# Altium schematic sheet p1_bt_sorry.SchDoc(p1_bt_sorry) (continued)

## Library data of the parts
C1 C10 C12 = 10uF 10V 0402: CAP CER 10UF 10V X5R 0402; Manufacturer Part Number: CL05A106MP5NUNC; Manufacturer: Samsung; Tolerance: 20%; Width: 0.02inch; Voltage Rating: 10V; Thickness: 0.028inch; Termination: Wraparound; Supplier Part Number 1: 1276-1450-1-ND; Supplier 1: Digi-Key; Stock 1: 2282441; Radiation Hardening: No; Pricing 1: 1=0.4, 10=0.277, 100=0.1663, 500=0.1247, 1000=0.1053, 2500=0.0998, 5000=0.0943 (USD); Packaging: Tape and Reel; Package Quantity: 1; Mount: Surface Mount; Min Operating Temperature: -55°C; Max Operating Temperature: 85°C; Library Name: Capacitors.SchLib; Length: 1mm; Lead Free: Lead Free; Dielectric: X5R; Depth: 0.5mm; Contact Plating: Nickel,Tin; ComponentLink2URL: https://datasheet.ciiva.com/26838/getdatasheetpartid-745310-26838170.pdf; ComponentLink2Description: https://datasheet.ciiva.com/26838/getdatasheetpartid-745310-26838170.pdf; ComponentLink1URL: https://datasheet.ciiva.com/25721/cl05a106mp5nunc-25721584.pdf; ComponentLink1Description: https://datasheet.ciiva.com/25721/cl05a106mp5nunc-25721584.pdf; Component Kind: Standard; Case Code (Metric): 1005; Case Code (Imperial): 0402; Case/Package: 0402; Capacitance: 10uF
C2 C3 C9 = 4.7uF 16V 0402: CAP CER 4.7UF 16V X5R 0402; Manufacturer Part Number: CL05A475MO5NUNC; Manufacturer: Samsung; Tolerance: 20%; Width: 0.02inch; Voltage Rating: 16V; Thickness: 0.022inch; Supplier Part Number 1: 1276-6836-1-ND; Supplier 1: Digi-Key; Stock 1: 282710; Pricing 1: 1=0.36, 10=0.248, 100=0.1485, 500=0.1114, 1000=0.0941, 2500=0.0891, 5000=0.0842 (USD); Packaging: Tape and Reel; Min Operating Temperature: -55°C; Max Operating Temperature: 85°C; Library Name: Capacitors.SchLib; Length: 0.039inch; Dielectric: X5R; Component Kind: Standard; Case Code (Metric): 1005; Case Code (Imperial): 0402; Case/Package: 0402; Capacitance: 4.7uF
C4 C6 = 1uF 25V 0402: CAP CER 1UF 25V X5R 0402; Manufacturer Part Number: TMK105BJ105MV-F; Manufacturer: Taiyo Yuden; Tolerance: 20%; Width: 0.02inch; Voltage Rating (DC): 25V; Voltage Rating: 25V; Thickness: 0.022inch; Termination: SMD/SMT; Supplier Part Number 1: 587-3245-1-ND; Supplier 1: Digi-Key; Stock 1: 113342; Radiation Hardening: No; Pricing 1: 1=0.2, 10=0.14, 100=0.066, 500=0.0463, 1000=0.0383, 2500=0.0357, 5000=0.0326 (USD); Packaging: Tape and Reel; Package Quantity: 1; Mount: Surface Mount; Min Operating Temperature: -55°C; Max Operating Temperature: 85°C; Library Name: Capacitors.SchLib; Length: 1mm; Height: 0.5mm; Halogen Free: Halogen Free; Dielectric: X5R; Depth: 0.5mm; Composition: Ceramic; ComponentLink4URL: https://datasheet.ciiva.com/30154/128tmk105bj105mv-f-30154639.pdf; ComponentLink4Description: https://datasheet.ciiva.com/30154/128tmk105bj105mv-f-30154639.pdf; ComponentLink3URL: https://datasheet.ciiva.com/13404/mlcc-all-e-541559-13404206.pdf; ComponentLink3Description: https://datasheet.ciiva.com/13404/mlcc-all-e-541559-13404206.pdf; ComponentLink2URL: https://datasheet.ciiva.com/15922/tmk105bj105mv-f-pdf-15922317.pdf; ComponentLink2Description: https://datasheet.ciiva.com/15922/tmk105bj105mv-f-pdf-15922317.pdf; ComponentLink1URL: https://datasheet.ciiva.com/11596/tmk105bj105mv-f-ss-11596466.pdf; ComponentLink1Description: https://datasheet.ciiva.com/11596/tmk105bj105mv-f-ss-11596466.pdf; Component Kind: Standard; Case Code (Metric): 1005; Case Code (Imperial): 0402; Case/Package: 0402; Capacitance: 1uF
C5 C7 C8 = 0.1uF 25V 0402: CAP CER 0.1UF 25V X7R 0402; Manufacturer Part Number: TMK105B7104KVHF; Manufacturer: Taiyo Yuden; Tolerance: 10%; Capacitance: 100nF; Width: 0.02inch; Weight: 2.3E-05oz; Voltage Rating (DC): 25V; Voltage Rating: 25V; Thickness: 0.022inch; Termination: SMD/SMT; Supplier Part Number 1: 587-3773-1-ND; Supplier 1: Digi-Key; Stock 1: 100000; Pricing 1: 1=0.12, 10=0.087, 100=0.0387, 500=0.02766, 1000=0.02173, 2500=0.01975, 5000=0.01817 (USD); Packaging: Tape and Reel; Package Quantity: 10000; Mount: Surface Mount; Min Operating Temperature: -55°C; Max Operating Temperature: 125°C; Library Name: Capacitors.SchLib; Length: 0.039inch; Lead Free: Lead Free; Height: 0.5mm; Halogen Free: Halogen Free; Dielectric: X7R; ComponentLink4URL: http://www.mouser.com/ds/2/396/mlcc11_hq_e-541564.pdf; ComponentLink4Description: http://www.mouser.com/ds/2/396/mlcc11_hq_e-541564.pdf; ComponentLink3URL: https://www.yuden.co.jp/productdata/catalog/mlcc01_hq_e.pdf; ComponentLink3Description: https://www.yuden.co.jp/productdata/catalog/mlcc01_hq_e.pdf; ComponentLink2URL: http://www.yuden.co.jp/ut/product/category/capacitor/putpdf/TMK105B7104KVHF_SS.pdf; ComponentLink2Description: http://www.yuden.co.jp/ut/product/category/capacitor/putpdf/TMK105B7104KVHF_SS.pdf; ComponentLink1URL: http://ds.yuden.co.jp/TYCOMPAS/ut/detail.do?productNo=TMK105B7104KVHF&fileName=TMK105B7104KVHF_SS&mode=specSheetDownload; ComponentLink1Description: http://ds.yuden.co.jp/TYCOMPAS/ut/detail.do?productNo=TMK105B7104KVHF&fileName=TMK105B7104KVHF_SS&mode=specSheetDownload; Component Kind: Standard; Case Code (Metric): 1005; Case Code (Imperial): 0402; Case/Package: 0402
C11 = 10000pF 25V 0402: CAP CER 10000PF 25V X7R 0402; Manufacturer Part Number: GRM155R71E103KA01D; Manufacturer: Murata; Tolerance: 10%; Material: Ceramic; Insulation Resistance: 10GR; Capacitance: 10nF; Width: 0.5mm; Voltage Rating (DC): 25V; Voltage Rating: 25V; Voltage: 25V; Thickness: 0.022inch; Termination: SMD/SMT; Supplier Part Number 1: 490-1312-1-ND; Supplier 1: Digi-Key; Stock 1: 4968292; REACH SVHC: No SVHC; Radiation Hardening: No; Pricing 1: 1=0.1, 10=0.014, 100=0.0064, 500=0.00456, 1000=0.00358, 2500=0.00325, 5000=0.00299 (USD); Packaging: Tape and Reel; Package Quantity: 1; Number of Pins: 2; Mount: Surface Mount; Min Operating Temperature: -55°C; Max Operating Temperature: 125°C; Library Name: Capacitors.SchLib; Length: 1mm; Lead Free: Lead Free; Height: 0.5mm; Dielectric Material: Ceramic; Dielectric: X7R; Depth: 0.5mm; ComponentLink10URL: https://datasheet.ciiva.com/29199/454154-da-01-en-vs-kondensator-0402-29199817.pdf; ComponentLink10Description: https://datasheet.ciiva.com/29199/454154-da-01-en-vs-kondensator-0402-29199817.pdf; ComponentLink9URL: https://datasheet.ciiva.com/129/partnumbering-e-01-129743.pdf; ComponentLink9Description: https://datasheet.ciiva.com/129/partnumbering-e-01-129743.pdf; ComponentLink8URL: https://datasheet.ciiva.com/129/partnumbering-e-01-129742.pdf; ComponentLink8Description: https://datasheet.ciiva.com/129/partnumbering-e-01-129742.pdf; ComponentLink7URL: https://datasheet.ciiva.com/129/partnumbering-e-01-129741.pdf; ComponentLink7Description: https://datasheet.ciiva.com/129/partnumbering-e-01-129741.pdf; ComponentLink6URL: https://datasheet.ciiva.com/3777/3813grm155r71e103ka0123-3777115.pdf; ComponentLink6Description: https://datasheet.ciiva.com/3777/3813grm155r71e103ka0123-3777115.pdf; ComponentLink5URL: https://datasheet.ciiva.com/16199/product-16199034.pdf; ComponentLink5Description: https://datasheet.ciiva.com/16199/product-16199034.pdf; ComponentLink4URL: https://datasheet.ciiva.com/7937/1747448-7937621.pdf; ComponentLink4Description: https://datasheet.ciiva.com/7937/1747448-7937621.pdf; ComponentLink3URL: https://datasheet.ciiva.com/28534/1699889-28534148.pdf; ComponentLink3Description: https://datasheet.ciiva.com/28534/1699889-28534148.pdf; ComponentLink2URL: https://datasheet.ciiva.com/18184/getdatasheetpartid-161489-18184689.pdf; ComponentLink2Description: https://datasheet.ciiva.com/18184/getdatasheetpartid-161489-18184689.pdf; ComponentLink1URL: https://datasheet.ciiva.com/11786/0900766b807a1cab-11786320.pdf; ComponentLink1Description: https://datasheet.ciiva.com/11786/0900766b807a1cab-11786320.pdf; Component Kind: Standard; Case Code (Metric): 1005; Case Code (Imperial): 0402; Case/Package: 0402
C13 = 0.015uF 16V 0402: CAP CER 0.015UF 16V X7R 0402; Manufacturer Part Number: GRM155R71C153KA01J; Manufacturer: Murata; Tolerance: 10%; Capacitance: 15nF; Width: 0.02inch; Voltage Rating (DC): 16V; Voltage Rating: 16V; Thickness: 0.022inch; Termination: SMD/SMT; Supplier Part Number 1: 490-6329-1-ND; Supplier 1: Digi-Key; Stock 1: 43188; Radiation Hardening: No; Pricing 1: 1=0.1, 10=0.044, 100=0.0197, 500=0.01408, 1000=0.01107, 2500=0.01006, 5000=0.00926 (USD); Packaging: Tape and Reel; Package Quantity: 1; Mount: Surface Mount; Min Operating Temperature: -55°C; Max Operating Temperature: 125°C; Library Name: Capacitors.SchLib; Length: 1mm; Height: 0.5mm; Dielectric: X7R; Depth: 0.5mm; ComponentLink3URL: https://datasheet.ciiva.com/6271/partnumbering-e-01-6271151.pdf; ComponentLink3Description: https://datasheet.ciiva.com/6271/partnumbering-e-01-6271151.pdf; ComponentLink2URL: https://datasheet.ciiva.com/11619/product-11619501.pdf; ComponentLink2Description: https://datasheet.ciiva.com/11619/product-11619501.pdf; ComponentLink1URL: https://datasheet.ciiva.com/6271/partnumbering-e-01-6271147.pdf; ComponentLink1Description: https://datasheet.ciiva.com/6271/partnumbering-e-01-6271147.pdf; Component Kind: Standard; Case Code (Metric): 1005; Case Code (Imperial): 0402; Case/Package: 0402
D1 = ESDAXLC6-1BU2: TVS DIODE 3V 17V ST0201; Manufacturer: STMicroelectronics; Test Current: 1mA; Power Line Protection: No; Polarity: Bidirectional; Peak Pulse Power: 40W; Peak Pulse Current: 1A; Number of Channels: 1; Min Breakdown Voltage: 6V; Max Surge Current: 1.3A; Max Reverse Leakage Current: 70nA; ESD Protection: Yes; Element Configuration: Single; Capacitance: 0.5pF; Width: 0.35mm; Termination: SMD/SMT; Supplier Part Number 1: 497-13414-1-ND; Supplier 1: Digi-Key; Stock 1: 25159; RoHS: Yes; Reverse Standoff Voltage: 3V; Radiation Hardening: No; Pricing 1: 1 = 0.42000, 10 = 0.31900, 100 = 0.19890, 500 = 0.13610, 1000 = 0.10469 (USD); Packaging: Tape and Reel; Package Quantity: 1; Number of Pins: 2; Number of Bidirectional Channels: 1; Mount: Surface Mount; Min Operating Temperature: -40°C; Max Operating Temperature: 150°C; Manufacturer Part Number: ESDAXLC6-1BU2; Library Name: Diodes.SchLib; Length: 0.6mm; Leakage Current: 70nA; Lead Free: Lead Free; Height: 0.33mm; Direction: Bidirectional; Depth: 0.3mm; Composition: Zener; ComponentLink10URL: https://datasheet.ciiva.com/41443/001183999-da-01-en-tvs-diode-3v-1-esdaxlc6-1bu2-st-0201-stm-41443438.pdf?src-supplier=Conrad; ComponentLink10Description: https://datasheet.ciiva.com/41443/001183999-da-01-en-tvs-diode-3v-1-esdaxlc6-1bu2-st-0201-stm-41443438.pdf?src-supplier=Conrad; ComponentLink9URL: https://datasheet.ciiva.com/24017/dm00065800-24017847.pdf?src-supplier=Mouser; ComponentLink9Description: https://datasheet.ciiva.com/24017/dm00065800-24017847.pdf?src-supplier=Mouser; ComponentLink8URL: https://datasheet.ciiva.com/24017/dm00065800-24017847.pdf?src-supplier=Avnet; ComponentLink8Description: https://datasheet.ciiva.com/24017/dm00065800-24017847.pdf?src-supplier=Avnet; ComponentLink7URL: https://datasheet.ciiva.com/4510/esd11b-d-4510297.pdf?src-supplier=Mouser; ComponentLink7Description: https://datasheet.ciiva.com/4510/esd11b-d-4510297.pdf?src-supplier=Mouser; ComponentLink6URL: https://datasheet.ciiva.com/11193/esd11b-d-11193656.pdf?src-supplier=Avnet; ComponentLink6Description: https://datasheet.ciiva.com/11193/esd11b-d-11193656.pdf?src-supplier=Avnet; ComponentLink5URL: https://datasheet.ciiva.com/6577/esd11b-d-6577815.pdf?src-supplier=Digi-Key; ComponentLink5Description: https://datasheet.ciiva.com/6577/esd11b-d-6577815.pdf?src-supplier=Digi-Key; ComponentLink4URL: https://datasheet.ciiva.com/6577/esd11b-d-6577814.pdf?src-supplier=Digi-Key; ComponentLink4Description: https://datasheet.ciiva.com/6577/esd11b-d-6577814.pdf?src-supplier=Digi-Key; ComponentLink3URL: http://www.onsemi.com/pub_link/Collateral/152AA.PDF; ComponentLink3Description: Package Specification; ComponentLink2URL: http://www.onsemi.cn/pub_link/Collateral/ESD11B-D.PDF; ComponentLink2Description: Datasheet; ComponentLink1URL: http://www.onsemi.com/; ComponentLink1Description: Manufacturer URL; Component Kind: Standard; Clamping Voltage: 17V; Case Code (Metric): 0603; Case Code (Imperial): 0201; Case/Package: 0201; Breakdown Voltage: 6V
D2 D6 = Green 0603 LED: LED GREEN CLEAR 0603 SMD; Manufacturer Part Number: 598-8070-107F; Manufacturer: Dialight; Wavelength: 570nm; Viewing Angle: 140°; Test Current: 20mA; Power Dissipation: 60mW; Number of LEDs: 1; Luminous Intensity: 20mcd; Lens Style: Clear,Diffused,Colorless,Rectangular; Forward Voltage: 2V; Dominant Wavelength: 568nm; Width: 0.8mm; Supplier Part Number 1: 350-2035-1-ND; Supplier 1: Digi-Key; Stock 1: 147636; REACH SVHC: No SVHC; Radiation Hardening: No; Pricing 1: 1=0.45, 10=0.327, 25=0.2504, 100=0.1634, 250=0.1198, 500=0.1016, 1000=0.0835 (USD); Packaging: Tape and Reel; Package Quantity: 4000; Number of Pins: 2; Number of Elements: 1; Mount: Surface Mount; Min Operating Temperature: -30°C; Max Operating Temperature: 100°C; Library Name: Diodes.SchLib; Lens Colour: Clear; Length: 1.6mm; Lead Free: Lead Free; Illumination Colour: Green; Height: 0.7mm; Forward Current: 20mA; Depth: 0.8mm; ComponentLink13URL: https://datasheet.ciiva.com/16400/smt-selector-guide-16400463.pdf; ComponentLink13Description: https://datasheet.ciiva.com/16400/smt-selector-guide-16400463.pdf; ComponentLink12URL: https://datasheet.ciiva.com/22749/1484480-22749228.pdf; ComponentLink12Description: https://datasheet.ciiva.com/22749/1484480-22749228.pdf; ComponentLink11URL: https://datasheet.ciiva.com/15117/getdatasheetpartid-146438-15117836.pdf; ComponentLink11Description: https://datasheet.ciiva.com/15117/getdatasheetpartid-146438-15117836.pdf; ComponentLink10URL: https://datasheet.ciiva.com/30188/mdei5980603-30188139.pdf; ComponentLink10Description: https://datasheet.ciiva.com/30188/mdei5980603-30188139.pdf; ComponentLink9URL: https://datasheet.ciiva.com/15965/mdei5980603-15965419.pdf; ComponentLink9Description: https://datasheet.ciiva.com/15965/mdei5980603-15965419.pdf; ComponentLink8URL: https://datasheet.ciiva.com/14605/70081990-14605530.pdf; ComponentLink8Description: https://datasheet.ciiva.com/14605/70081990-14605530.pdf; ComponentLink7URL: https://datasheet.ciiva.com/2110/598-series-0603-pkg-2110167.pdf; ComponentLink7Description: https://datasheet.ciiva.com/2110/598-series-0603-pkg-2110167.pdf; ComponentLink6URL: https://datasheet.ciiva.com/2110/598-series-0603-pkg-2110166.pdf; ComponentLink6Description: https://datasheet.ciiva.com/2110/598-series-0603-pkg-2110166.pdf; ComponentLink5URL: https://datasheet.ciiva.com/1243/1484480-1243894.pdf; ComponentLink5Description: https://datasheet.ciiva.com/1243/1484480-1243894.pdf; ComponentLink4URL: https://datasheet.ciiva.com/2110/598-series-0603-pkg-2110165.pdf; ComponentLink4Description: https://datasheet.ciiva.com/2110/598-series-0603-pkg-2110165.pdf; ComponentLink3URL: https://datasheet.ciiva.com/1243/1484480-1243893.pdf; ComponentLink3Description: https://datasheet.ciiva.com/1243/1484480-1243893.pdf; ComponentLink2URL: https://datasheet.ciiva.com/11774/0900766b80ae2975-11774257.pdf; ComponentLink2Description: https://datasheet.ciiva.com/11774/0900766b80ae2975-11774257.pdf; ComponentLink1URL: https://datasheet.ciiva.com/4688/598-selectorguide-18902-4688880.pdf; ComponentLink1Description: https://datasheet.ciiva.com/4688/598-selectorguide-18902-4688880.pdf; Component Kind: Standard; Colour: Green; Case Code (Metric): 1608; Case Code (Imperial): 0603; Case/Package: 0603
D3 = MMBD914LT1G: DIODE GEN PURP 100V 200MA SOT23; Manufacturer: ON Semiconductor; Reverse Recovery Time: 4ns; Power Dissipation: 300mW; Polarity: Standard; Peak Reverse Current: 5uA; Peak Non-Repetitive Surge Current: 500mA; Forward Voltage: 1V; Element Configuration: Single; Capacitance: 4pF; Width: 0.055inch; Voltage Rating (DC): 100V; Supplier Part Number 1: MMBD914LT1GOSCT-ND; Supplier 1: Digi-Key; Stock 1: 1313496; RoHS: Yes; Recovery Time: 4ns; REACH SVHC: No SVHC; Radiation Hardening: No; Pricing 1: 1 = 0.11000, 10 = 0.10000, 100 = 0.05450, 500 = 0.03352, 1000 = 0.02286 (USD); Packaging: Tape and Reel; Package Quantity: 1; Output Current: 200mA; Number of Pins: 3; Min Operating Temperature: -55°C; Max Surge Current: 500mA; Max Reverse Voltage (DC): 100V; Max Reverse Leakage Current: 5uA; Max Repetitive Reverse Voltage (Vrrm): 100V; Max Operating Temperature: 150°C; Max Forward Surge Current (Ifsm): 500mA; Manufacturer Part Number: MMBD914LT1G; Library Name: Diodes.SchLib; Length: 0.119inch; Lead Free: Lead Free; Height: 0.04inch; Halogen Free: Halogen Free; Forward Current: 200mA; Current Rating: 200mA; Contact Plating: Tin; ComponentLink8URL: https://datasheet.ciiva.com/16474/mmbd914lt1-d-16474303.pdf?src-supplier=Avnet+Asia; ComponentLink8Description: https://datasheet.ciiva.com/16474/mmbd914lt1-d-16474303.pdf?src-supplier=Avnet+Asia; ComponentLink7URL: https://datasheet.ciiva.com/16209/mmbd914lt1-d-16209147.pdf?src-supplier=Future+Electronics; ComponentLink7Description: https://datasheet.ciiva.com/16209/mmbd914lt1-d-16209147.pdf?src-supplier=Future+Electronics; ComponentLink6URL: https://datasheet.ciiva.com/22663/1571903-22663685.pdf?src-supplier=Element14; ComponentLink6Description: https://datasheet.ciiva.com/22663/1571903-22663685.pdf?src-supplier=Element14; ComponentLink5URL: https://datasheet.ciiva.com/1181/mmbd914lt1-d-1181205.pdf?src-supplier=Element14; ComponentLink5Description: https://datasheet.ciiva.com/1181/mmbd914lt1-d-1181205.pdf?src-supplier=Element14; ComponentLink4URL: https://datasheet.ciiva.com/1181/mmbd914lt1-d-1181204.pdf?src-supplier=Element14; ComponentLink4Description: https://datasheet.ciiva.com/1181/mmbd914lt1-d-1181204.pdf?src-supplier=Element14; ComponentLink3URL: https://datasheet.ciiva.com/915/mmbd914lt1-d-915329.pdf?src-supplier=Digi-Key; ComponentLink3Description: https://datasheet.ciiva.com/915/mmbd914lt1-d-915329.pdf?src-supplier=Digi-Key; ComponentLink2URL: https://datasheet.ciiva.com/915/mmbd914lt1-d-915328.pdf?src-supplier=Digi-Key; ComponentLink2Description: https://datasheet.ciiva.com/915/mmbd914lt1-d-915328.pdf?src-supplier=Digi-Key; ComponentLink1URL: https://datasheet.ciiva.com/1243/mmbd914lt1-d-1243184.pdf?src-supplier=Newark; ComponentLink1Description: https://datasheet.ciiva.com/1243/mmbd914lt1-d-1243184.pdf?src-supplier=Newark; Component Kind: Standard; Case/Package: SOT-23-3; Average Rectified Current: 200mA
D4 = PMEG2020EPK,315: DIODE SCHOTTKY 20V 2A 2DFN; Manufacturer: NXP Semiconductors; Reverse Recovery Time: 5ns; Peak Reverse Current: 70uA; Peak Non-Repetitive Surge Current: 5A; Natural Thermal Resistance: 20°C/W; Max Power Dissipation: 1.565W; Forward Voltage: 395mV; Element Configuration: Single; Supplier Part Number 1: 1727-1338-1-ND; Supplier 1: Digi-Key; Stock 1: 146420; Reverse Voltage (DC): 20V; Radiation Hardening: No; Pricing 1: 1=0.51, 10=0.387, 100=0.2411, 500=0.165, 1000=0.1269 (USD); Packaging: Tape and Reel; Package Quantity: 8000; Number of Pins: 2; Mount: Surface Mount; Min Operating Temperature: -55°C; Max Repetitive Reverse Voltage (Vrrm): 20V; Max Operating Temperature: 150°C; Max Forward Surge Current (Ifsm): 5A; Manufacturer Part Number: PMEG2020EPK,315; Library Name: Diodes.SchLib; Forward Current: 2A; ComponentLink4URL: https://datasheet.ciiva.com/25648/pmeg2020epk-25648600.pdf; ComponentLink4Description: https://datasheet.ciiva.com/25648/pmeg2020epk-25648600.pdf; ComponentLink3URL: https://datasheet.ciiva.com/24111/pmeg2020epk-268880-24111063.pdf; ComponentLink3Description: https://datasheet.ciiva.com/24111/pmeg2020epk-268880-24111063.pdf; ComponentLink2URL: https://datasheet.ciiva.com/29592/pmeg2020epk-29592901.pdf; ComponentLink2Description: https://datasheet.ciiva.com/29592/pmeg2020epk-29592901.pdf; ComponentLink1URL: https://datasheet.ciiva.com/29592/pmeg2020epk-29592900.pdf; ComponentLink1Description: https://datasheet.ciiva.com/29592/pmeg2020epk-29592900.pdf; Component Kind: Standard; Case/Package: SMD/SMT; Average Rectified Current: 2A
D5 = Blue 0603 LED: LED BLUE CLEAR 0603 SMD; Manufacturer Part Number: 598-8091-107F; Manufacturer: Dialight; Wavelength: 475nm; Viewing Angle: 140°; Test Current: 20mA; Power Dissipation: 70mW; Number of LEDs: 1; Luminous Intensity: 140mcd; Lens Style: Clear,Diffused,Colorless,Rectangular; Lens Colour: Clear,Colorless; Forward Voltage: 3.2V; Dominant Wavelength: 470nm; Width: 0.8mm; Supplier Part Number 1: 350-2037-1-ND; Supplier 1: Digi-Key; Stock 1: 42042; REACH SVHC: No SVHC; Radiation Hardening: No; Pricing 1: 1=0.75, 10=0.583, 25=0.4864, 100=0.4189, 250=0.374, 500=0.3216, 1000=0.2483 (USD); Packaging: Tape and Reel; Package Quantity: 4000; Number of Pins: 2; Number of Elements: 1; Mount: Surface Mount; Min Operating Temperature: -30°C; Max Operating Temperature: 100°C; Library Name: Diodes.SchLib; Lens Transparency: Clear; Length: 1.6mm; Lead Free: Lead Free; Illumination Colour: Blue; Height: 0.7mm; Forward Current: 20mA; Depth: 0.8mm; ComponentLink9URL: https://datasheet.ciiva.com/16400/smt-selector-guide-16400478.pdf; ComponentLink9Description: https://datasheet.ciiva.com/16400/smt-selector-guide-16400478.pdf; ComponentLink8URL: https://datasheet.ciiva.com/14603/70081992-14603963.pdf; ComponentLink8Description: https://datasheet.ciiva.com/14603/70081992-14603963.pdf; ComponentLink7URL: https://datasheet.ciiva.com/7970/25658-7970182.pdf; ComponentLink7Description: https://datasheet.ciiva.com/7970/25658-7970182.pdf; ComponentLink6URL: https://datasheet.ciiva.com/2111/598-series-0603-pkg-2111558.pdf; ComponentLink6Description: https://datasheet.ciiva.com/2111/598-series-0603-pkg-2111558.pdf; ComponentLink5URL: https://datasheet.ciiva.com/30240/mdei5980603-30240533.pdf; ComponentLink5Description: https://datasheet.ciiva.com/30240/mdei5980603-30240533.pdf; ComponentLink4URL: https://datasheet.ciiva.com/15965/mdei5980603-15965445.pdf; ComponentLink4Description: https://datasheet.ciiva.com/15965/mdei5980603-15965445.pdf; ComponentLink3URL: https://datasheet.ciiva.com/2111/598-series-0603-pkg-2111557.pdf; ComponentLink3Description: https://datasheet.ciiva.com/2111/598-series-0603-pkg-2111557.pdf; ComponentLink2URL: https://datasheet.ciiva.com/2111/598-series-0603-pkg-2111556.pdf; ComponentLink2Description: https://datasheet.ciiva.com/2111/598-series-0603-pkg-2111556.pdf; ComponentLink1URL: https://datasheet.ciiva.com/15118/getdatasheetpartid-194139-15118048.pdf; ComponentLink1Description: https://datasheet.ciiva.com/15118/getdatasheetpartid-194139-15118048.pdf; Component Kind: Standard; Colour: Blue; Case Code (Metric): 1608; Case Code (Imperial): 0603; Case/Package: 0603
J1 = USB-C Vertical: CONN RCPT USB3.1 TYPEC 24POS SMD; Manufacturer: Amphenol FCI; Supplier Part Number 1: 609-5380-1-ND; Supplier 1: Digi-Key; Stock 1: 6832; RoHS: No; Pricing 1: 1 = 2.67000, 10 = 2.41900, 25 = 2.27120, 50 = 2.17240, 100 = 2.07370 (USD); Manufacturer Part Number: 10132328-10011LF; Library Name: Connectors.SchLib; ComponentLink1URL: http://www.amphenol-icc.com/media/wysiwyg/files/drawing/10132328.pdf?src-supplier=Digi-Key; ComponentLink1Description: http://www.amphenol-icc.com/media/wysiwyg/files/drawing/10132328.pdf?src-supplier=Digi-Key; Component Kind: Standard
J2 = Tag Connect 6-Pin SWD: TAG CONNECT 6PIN SWD; Library Name: Connectors.SchLib; Component Kind: Standard
J3 = JST ZH 2-Pin PTH Vertical: CONN HEADER ZH TOP 2POS 1.5MM; Manufacturer: JST; Voltage Rating (DC): 50V; Panel Thickness: 3.5mm; Number of Positions: 2; Max Current Rating: 1A; Insulation Resistance: 500MR; Gender: Male; Contact Resistance: 30mR; Connector Type: Male Pin; Body Plating: Tin; Voltage Rating (AC): 50V; Voltage Rating: 50V; Termination: Solder; Supplier Part Number 1: 455-1657-ND; Supplier 1: Digi-Key; Stock 1: 123534; RoHS: Yes; REACH SVHC: No SVHC; Pricing 1: 1 = 0.17000, 10 = 0.16200, 100 = 0.09990, 500 = 0.08270, 1000 = 0.07237, 5000 = 0.06203, 10000 = 0.06031 (USD); Pitch: 1.5mm; Packaging: Bulk; Orientation: Straight; Number of Rows: 1; Number of Contacts: 2; Mount: Through Hole; Min Operating Temperature: -25°C; Max Voltage Rating (AC): 50V; Max Operating Temperature: 85°C; Material: Nylon; Manufacturer Part Number: B2B-ZR(LF)(SN); Library Name: Connectors.SchLib; Length: 4.5mm; Lead Pitch: 1.5mm; Lead Free: Lead Free; Housing Material: Polyamide; Housing Color: Beige; Flammability Rating: UL94 V-0; Fastening Type: Detent; Depth: 3.5mm; Current Rating: 1A; Contact Plating: Tin; Contact Mating Length: 0.094inch; Contact Material: Brass; ComponentLink5URL: https://datasheet.ciiva.com/712/ezh-712443.pdf?src-supplier=Digi-Key; ComponentLink5Description: https://datasheet.ciiva.com/712/ezh-712443.pdf?src-supplier=Digi-Key; ComponentLink4URL: https://datasheet.ciiva.com/8052/80551-8052026.pdf?src-supplier=Element14; ComponentLink4Description: https://datasheet.ciiva.com/8052/80551-8052026.pdf?src-supplier=Element14; ComponentLink3URL: https://datasheet.ciiva.com/25616/0900766b81147f94-25616906.pdf?src-supplier=RSComponents; ComponentLink3Description: https://datasheet.ciiva.com/25616/0900766b81147f94-25616906.pdf?src-supplier=RSComponents; ComponentLink2URL: https://datasheet.ciiva.com/11752/0900766b8082f580-11752167.pdf?src-supplier=RSComponents; ComponentLink2Description: https://datasheet.ciiva.com/11752/0900766b8082f580-11752167.pdf?src-supplier=RSComponents; ComponentLink1URL: https://datasheet.ciiva.com/26894/getdatasheetpartid-643133-26894438.pdf?src-supplier=Verical; ComponentLink1Description: https://datasheet.ciiva.com/26894/getdatasheetpartid-643133-26894438.pdf?src-supplier=Verical; Component Kind: Standard; Color: Natural
J4 = 10-Pin 2x5 2mm Header: CONN HEADER SMD 10POS 2MM; Manufacturer: Harwin; Row Spacing: 0.079inch; Number of Positions: 10; Max Current Rating: 1A; Gender: Male; Contact Resistance: 30mR; Connector Type: Male Pin; Termination: Solder; Supplier Part Number 1: 952-3345-ND; Supplier 1: Digikey; Stock 1: 6436; RoHS: Yes; Radiation Hardening: No; Pricing 1: 1 = 0.76000, 10 = 0.66600, 100 = 0.57420, 500 = 0.46980, 1000 = 0.41760, 5000 = 0.36540, 10000 = 0.34713 (USD); Pitch: 2mm; Packaging: Rail/Tube; Package Quantity: 250; Orientation: Straight; Number of Rows: 2; Number of Contacts: 10; Mount: Surface Mount; Min Operating Temperature: -40°C; Max Operating Temperature: 105°C; Manufacturer Part Number: M22-5320546; Library Name: Connectors.SchLib; Length: 10mm; Housing Material: Nylon; Housing Color: Black; Depth: 5.75mm; Contact Plating: Tin; Contact Mating Length: 0.138inch; Contact Material: Bronze; ComponentLink1URL: https://datasheet.ciiva.com/16735/m22-532-16735560.pdf?src-supplier=Avnet+Europe; ComponentLink1Description: https://datasheet.ciiva.com/16735/m22-532-16735560.pdf?src-supplier=Avnet+Europe; Component Kind: Standard; Color: Black
Q1 = DMP21D0UFB4-7B: MOSFET P-CH 20V 770MA 3DFN; Manufacturer: Diodes; Turn-On Delay Time: 7.1ns; Turn-Off Delay Time: 31.7ns; Rise Time: 8ns; Rds On Max: 495mR; Power Dissipation: 990mW; Number of Elements: 1; Input Capacitance: 80pF; Gate to Source Voltage (Vgs): 8V; Fall Time: 18.5ns; Element Configuration: Single; Drain to Source Resistance: 960mR; Drain to Source Breakdown Voltage: -20V; Continuous Drain Current (ID): 1.17A; Width: 0.65mm; Supplier Part Number 1: DMP21D0UFB4-7BDICT-ND; Supplier 1: Digi-Key; Stock 1: 167177; REACH SVHC: No SVHC; Radiation Hardening: No; Pricing 1: 1=0.4, 10=0.303, 100=0.1886, 500=0.129, 1000=0.0992 (USD); Packaging: Tape and Reel; Package Quantity: 1; Number of Pins: 3; Number of Channels: 1; Mount: Surface Mount; Min Operating Temperature: -55°C; Max Power Dissipation: 990mW; Max Operating Temperature: 150°C; Manufacturer Part Number: DMP21D0UFB4-7B; Library Name: ICs.SchLib; Length: 1.05mm; Lead Free: Lead Free; Height: 0.35mm; Drain to Source Voltage (Vdss): 20V; Contact Plating: Gold; ComponentLink6URL: https://datasheet.ciiva.com/13051/dmp21d0ufb4-13051690.pdf; ComponentLink6Description: https://datasheet.ciiva.com/13051/dmp21d0ufb4-13051690.pdf; ComponentLink5URL: https://datasheet.ciiva.com/30479/0900766b8115c367-30479913.pdf; ComponentLink5Description: https://datasheet.ciiva.com/30479/0900766b8115c367-30479913.pdf; ComponentLink4URL: https://datasheet.ciiva.com/30023/dmp21d0ufb4-30023815.pdf; ComponentLink4Description: https://datasheet.ciiva.com/30023/dmp21d0ufb4-30023815.pdf; ComponentLink3URL: https://datasheet.ciiva.com/6369/dmp21d0ufb4-6369015.pdf; ComponentLink3Description: https://datasheet.ciiva.com/6369/dmp21d0ufb4-6369015.pdf; ComponentLink2URL: https://datasheet.ciiva.com/6369/dmp21d0ufb4-6369014.pdf; ComponentLink2Description: https://datasheet.ciiva.com/6369/dmp21d0ufb4-6369014.pdf; ComponentLink1URL: https://datasheet.ciiva.com/6369/dmp21d0ufb4-6369012.pdf; ComponentLink1Description: https://datasheet.ciiva.com/6369/dmp21d0ufb4-6369012.pdf; Component Kind: Standard; Case/Package: DFN
R1 R2 = 5.1k 0402: RES SMD 5.1K OHM 1% 1/16W 0402; Manufacturer Part Number: RC0402FR-075K1L; Manufacturer: Yageo; Working Voltage: 50V; Temperature Coefficient: 100ppm/°C; Resistance: 5.1kR; Power Rating: 63mW; Width: 0.02inch; Voltage Rating (DC): 50V; Voltage Rating: 50V; Tolerance: 1%; Termination: SMD/SMT; Supplier Part Number 1: 311-5.10KLRCT-ND; Supplier 1: Digi-Key; Stock 1: 3990797; RoHS: Yes; REACH SVHC: Unknown; Pricing 1: 1 = 0.10000, 10 = 0.01600, 100 = 0.00670, 1000 = 0.00301, 2500 = 0.00261, 5000 = 0.00215 (USD); Packaging: Tape and Reel; Package Quantity: 1; Number of Terminations: 2; Min Operating Temperature: -55°C; Military Standard: Not; Max Power Dissipation: 63mW; Max Operating Temperature: 155°C; Library Name: Resistors.SchLib; Length: 1mm; Lead Free: Lead Free; Height: 0.016inch; Features: Moisture Resistant; Depth: 0.5mm; Composition: Thick Film; ComponentLink8URL: https://datasheet.ciiva.com/7983/1018594-7983519.pdf?src-supplier=Element14; ComponentLink8Description: https://datasheet.ciiva.com/7983/1018594-7983519.pdf?src-supplier=Element14; ComponentLink7URL: https://datasheet.ciiva.com/12558/pyu-rc0402-51-rohs-l-6-r-8721-12558460.pdf?src-supplier=Mouser; ComponentLink7Description: https://datasheet.ciiva.com/12558/pyu-rc0402-51-rohs-l-6-r-8721-12558460.pdf?src-supplier=Mouser; ComponentLink6URL: https://datasheet.ciiva.com/16744/pyu-rc0402-51-rohs-l-6-r-16744283.pdf?src-supplier=Future+Electronics; ComponentLink6Description: https://datasheet.ciiva.com/16744/pyu-rc0402-51-rohs-l-6-r-16744283.pdf?src-supplier=Future+Electronics; ComponentLink5URL: https://datasheet.ciiva.com/2453/pyu-r-int-thick-7-2453402.pdf?src-supplier=Digi-Key; ComponentLink5Description: https://datasheet.ciiva.com/2453/pyu-r-int-thick-7-2453402.pdf?src-supplier=Digi-Key; ComponentLink4URL: https://datasheet.ciiva.com/2453/pyu-r-int-thick-7-2453401.pdf?src-supplier=Digi-Key; ComponentLink4Description: https://datasheet.ciiva.com/2453/pyu-r-int-thick-7-2453401.pdf?src-supplier=Digi-Key; ComponentLink3URL: https://datasheet.ciiva.com/46394/1018594-46394936.pdf?src-supplier=Element14; ComponentLink3Description: https://datasheet.ciiva.com/46394/1018594-46394936.pdf?src-supplier=Element14; ComponentLink2URL: https://datasheet.ciiva.com/2453/pyu-r-int-thick-7-2453400.pdf?src-supplier=Digi-Key; ComponentLink2Description: https://datasheet.ciiva.com/2453/pyu-r-int-thick-7-2453400.pdf?src-supplier=Digi-Key; ComponentLink1URL: https://datasheet.ciiva.com/15189/getdatasheetpartid-323636-15189493.pdf?src-supplier=Verical; ComponentLink1Description: https://datasheet.ciiva.com/15189/getdatasheetpartid-323636-15189493.pdf?src-supplier=Verical; Component Kind: Standard; Case Code (Metric): 1005; Case Code (Imperial): 0402; Case/Package: 0402
R3 R15 = 47R 0402: RES SMD 47 OHM 1% 1/16W 0402; Manufacturer Part Number: RC0402FR-0747RL; Manufacturer: Yageo; Voltage Rating: 50V; Temperature Coefficient: 100ppm/°C; Power Rating: 63mW; Width: 0.02inch; Weight: 2.3E-05oz; Tolerance: 1%; Termination: SMD/SMT; Supplier Part Number 1: 311-47.0LRCT-ND; Supplier 1: Digi-Key; Stock 1: 2791305; RoHS: Yes; Resistance: 47R; REACH SVHC: Unknown; Pricing 1: 1 = 0.10000, 10 = 0.01600, 100 = 0.00670, 1000 = 0.00301, 2500 = 0.00261, 5000 = 0.00215 (USD); Packaging: Tape and Reel; Package Quantity: 1; Number of Terminations: 2; Min Operating Temperature: -55°C; Military Standard: Not; Max Power Dissipation: 63mW; Max Operating Temperature: 155°C; Library Name: Resistors.SchLib; Length: 1mm; Lead Free: Lead Free; Height: 0.016inch; Features: Moisture Resistant; Depth: 0.5mm; Composition: Thick Film; ComponentLink7URL: https://datasheet.ciiva.com/17067/pyu-rc0402-51-rohs-l-6-r-17067470.pdf?src-supplier=Future+Electronics; ComponentLink7Description: https://datasheet.ciiva.com/17067/pyu-rc0402-51-rohs-l-6-r-17067470.pdf?src-supplier=Future+Electronics; ComponentLink6URL: https://datasheet.ciiva.com/15189/getdatasheetpartid-329266-15189467.pdf?src-supplier=Verical; ComponentLink6Description: https://datasheet.ciiva.com/15189/getdatasheetpartid-329266-15189467.pdf?src-supplier=Verical; ComponentLink5URL: https://datasheet.ciiva.com/12577/pyu-rc0402-51-rohs-l-6-r-12577592.pdf?src-supplier=Mouser; ComponentLink5Description: https://datasheet.ciiva.com/12577/pyu-rc0402-51-rohs-l-6-r-12577592.pdf?src-supplier=Mouser; ComponentLink4URL: https://datasheet.ciiva.com/2553/pyu-r-int-thick-7-2553223.pdf?src-supplier=Digi-Key; ComponentLink4Description: https://datasheet.ciiva.com/2553/pyu-r-int-thick-7-2553223.pdf?src-supplier=Digi-Key; ComponentLink3URL: https://datasheet.ciiva.com/2553/pyu-r-int-thick-7-2553222.pdf?src-supplier=Digi-Key; ComponentLink3Description: https://datasheet.ciiva.com/2553/pyu-r-int-thick-7-2553222.pdf?src-supplier=Digi-Key; ComponentLink2URL: https://datasheet.ciiva.com/2553/pyu-r-int-thick-7-2553221.pdf?src-supplier=Digi-Key; ComponentLink2Description: https://datasheet.ciiva.com/2553/pyu-r-int-thick-7-2553221.pdf?src-supplier=Digi-Key; ComponentLink1URL: https://datasheet.ciiva.com/11819/0900766b80c66297-11819509.pdf?src-supplier=RSComponents; ComponentLink1Description: https://datasheet.ciiva.com/11819/0900766b80c66297-11819509.pdf?src-supplier=RSComponents; Component Kind: Standard; Case Code (Metric): 1005; Case Code (Imperial): 0402; Case/Package: 0402
R4 R11 = 10k 0402: RES SMD 10K OHM 1% 1/16W 0402; Manufacturer Part Number: RC0402FR-0710KL; Manufacturer: Yageo Phycomp; Voltage Rating (DC): 50V; Temperature Coefficient: 100ppm/°C; Resistance: 10000R; Power Rating: 63mW; Width: 500um; Tolerance: 1%; Supplier Part Number 1: 311-10.0KLRCT-ND; Supplier 1: Digi-Key; Stock 1: 98435877; RoHS: Yes; REACH SVHC: No SVHC; Pricing 1: 1 = 0.10000, 10 = 0.01600, 100 = 0.00670, 1000 = 0.00301, 2500 = 0.00261, 5000 = 0.00215 (USD); Packaging: Cut Tape; Number of Pins: 2; Mount: Surface Mount; Min Operating Temperature: -55°C; Max Operating Temperature: 155°C; Library Name: Resistors.SchLib; Length: 1mm; Lead Free: Lead Free; Height: 0.32mm; Depth: 0.5mm; ComponentLink7URL: http://documents.rs-components.com/techlib://itc_doclist_GB.htm?stockno=6185832&src-supplier=RSComponents; ComponentLink7Description: http://documents.rs-components.com/techlib://itc_doclist_GB.htm?stockno=6185832&src-supplier=RSComponents; ComponentLink6URL: http://www.farnell.com/datasheets/1784430.pdf?src-supplier=Element14; ComponentLink6Description: http://www.farnell.com/datasheets/1784430.pdf?src-supplier=Element14; ComponentLink5URL: http://www.farnell.com/datasheets/2167052.pdf?src-supplier=Element14; ComponentLink5Description: http://www.farnell.com/datasheets/2167052.pdf?src-supplier=Element14; ComponentLink4URL: http://www.farnell.com/datasheets/2167052.pdf?src-supplier=Newark; ComponentLink4Description: http://www.farnell.com/datasheets/2167052.pdf?src-supplier=Newark; ComponentLink3URL: http://www.farnell.com/datasheets/1738692.pdf?src-supplier=Element14; ComponentLink3Description: http://www.farnell.com/datasheets/1738692.pdf?src-supplier=Element14; ComponentLink2URL: http://www.yageo.com/documents/recent/PYu-RC_Group_51_RoHS_L_10.pdf?src-supplier=Digi-Key; ComponentLink2Description: http://www.yageo.com/documents/recent/PYu-RC_Group_51_RoHS_L_10.pdf?src-supplier=Digi-Key; ComponentLink1URL: http://www.farnell.com/datasheets/2167052.pdf?src-supplier=Farnell; ComponentLink1Description: http://www.farnell.com/datasheets/2167052.pdf?src-supplier=Farnell; Component Kind: Standard; Case/Package: 0402
R5 R9 R16 = 1k 0402: RES SMD 1K OHM 1% 1/16W 0402; Manufacturer Part Number: RC0402FR-071KL; Manufacturer: Yageo; Voltage Rating (DC): 50V; Temperature Coefficient: 100ppm/°C; Resistance: 1kR; Power Rating: 62.5mW; Width: 0.5mm; Voltage Rating: 50V; Tolerance: 1%; Termination: SMD/SMT; Supplier Part Number 1: 311-1.00KLRCT-ND; Supplier 1: Digi-Key; Stock 1: 72757571; RoHS: Yes; REACH SVHC: Unknown; Pricing 1: 1 = 0.10000, 10 = 0.01600, 100 = 0.00670, 1000 = 0.00301, 2500 = 0.00261, 5000 = 0.00215 (USD); Packaging: Tape and Reel; Number of Pins: 2; Min Operating Temperature: -55°C; Military Standard: Not; Max Operating Temperature: 155°C; Library Name: Resistors.SchLib; Length: 1mm; Lead Free: Lead Free; Height: 0.32mm; Depth: 0.5mm; Composition: Thick Film; ComponentLink7URL: https://datasheet.ciiva.com/7849/1018594-7849871.pdf?src-supplier=Element14; ComponentLink7Description: https://datasheet.ciiva.com/7849/1018594-7849871.pdf?src-supplier=Element14; ComponentLink6URL: https://datasheet.ciiva.com/7983/1018594-7983502.pdf?src-supplier=Element14; ComponentLink6Description: https://datasheet.ciiva.com/7983/1018594-7983502.pdf?src-supplier=Element14; ComponentLink5URL: https://datasheet.ciiva.com/7849/1018594-7849869.pdf?src-supplier=Element14; ComponentLink5Description: https://datasheet.ciiva.com/7849/1018594-7849869.pdf?src-supplier=Element14; ComponentLink4URL: https://datasheet.ciiva.com/22747/1018594-22747513.pdf?src-supplier=Element14; ComponentLink4Description: https://datasheet.ciiva.com/22747/1018594-22747513.pdf?src-supplier=Element14; ComponentLink3URL: https://datasheet.ciiva.com/17063/pyu-rc0402-51-rohs-l-6-r-17063193.pdf?src-supplier=Future+Electronics; ComponentLink3Description: https://datasheet.ciiva.com/17063/pyu-rc0402-51-rohs-l-6-r-17063193.pdf?src-supplier=Future+Electronics; ComponentLink2URL: https://datasheet.ciiva.com/12666/pyu-rc0402-51-rohs-l-6-r-12666741.pdf?src-supplier=Mouser; ComponentLink2Description: https://datasheet.ciiva.com/12666/pyu-rc0402-51-rohs-l-6-r-12666741.pdf?src-supplier=Mouser; ComponentLink1URL: https://datasheet.ciiva.com/11819/0900766b80c66297-11819477.pdf?src-supplier=RSComponents; ComponentLink1Description: https://datasheet.ciiva.com/11819/0900766b80c66297-11819477.pdf?src-supplier=RSComponents; Component Kind: Standard; Case Code (Metric): 1005; Case Code (Imperial): 0402; Case/Package: 0402
R6 = 2k 0402: RES SMD 2K OHM 1% 1/16W 0402; Manufacturer Part Number: RC0402FR-072KL; Manufacturer: Yageo; Working Voltage: 50V; Temperature Coefficient: 100ppm/°C; Resistance: 2kR; Power Rating: 63mW; Width: 0.02inch; Voltage Rating (DC): 50V; Voltage Rating: 50V; Tolerance: 1%; Termination: SMD/SMT; Supplier Part Number 1: 311-2KLRCT-ND; Supplier 1: Digi-Key; Stock 1: 13397188; RoHS: Yes; REACH SVHC: Unknown; Pricing 1: 1 = 0.10000, 10 = 0.01600, 100 = 0.00670, 1000 = 0.00301, 2500 = 0.00261, 5000 = 0.00215 (USD); Packaging: Tape and Reel; Package Quantity: 1; Number of Terminations: 2; Min Operating Temperature: -55°C; Military Standard: Not; Max Power Dissipation: 63mW; Max Operating Temperature: 155°C; Library Name: Resistors.SchLib; Length: 1mm; Lead Free: Lead Free; Height: 0.016inch; Features: Moisture Resistant; Depth: 0.5mm; Composition: Thick Film; ComponentLink9URL: https://datasheet.ciiva.com/7849/1018594-7849935.pdf?src-supplier=Element14; ComponentLink9Description: https://datasheet.ciiva.com/7849/1018594-7849935.pdf?src-supplier=Element14; ComponentLink8URL: https://datasheet.ciiva.com/7849/1018594-7849934.pdf?src-supplier=Element14; ComponentLink8Description: https://datasheet.ciiva.com/7849/1018594-7849934.pdf?src-supplier=Element14; ComponentLink7URL: https://datasheet.ciiva.com/7983/1018594-7983517.pdf?src-supplier=Element14; ComponentLink7Description: https://datasheet.ciiva.com/7983/1018594-7983517.pdf?src-supplier=Element14; ComponentLink6URL: https://datasheet.ciiva.com/12667/pyu-rc0402-51-rohs-l-6-r-12667451.pdf?src-supplier=Mouser; ComponentLink6Description: https://datasheet.ciiva.com/12667/pyu-rc0402-51-rohs-l-6-r-12667451.pdf?src-supplier=Mouser; ComponentLink5URL: https://datasheet.ciiva.com/15189/getdatasheetpartid-358921-15189414.pdf?src-supplier=Verical; ComponentLink5Description: https://datasheet.ciiva.com/15189/getdatasheetpartid-358921-15189414.pdf?src-supplier=Verical; ComponentLink4URL: https://datasheet.ciiva.com/2453/pyu-r-int-thick-7-2453636.pdf?src-supplier=Digi-Key; ComponentLink4Description: https://datasheet.ciiva.com/2453/pyu-r-int-thick-7-2453636.pdf?src-supplier=Digi-Key; ComponentLink3URL: https://datasheet.ciiva.com/2453/pyu-r-int-thick-7-2453635.pdf?src-supplier=Digi-Key; ComponentLink3Description: https://datasheet.ciiva.com/2453/pyu-r-int-thick-7-2453635.pdf?src-supplier=Digi-Key; ComponentLink2URL: https://datasheet.ciiva.com/2453/pyu-r-int-thick-7-2453634.pdf?src-supplier=Digi-Key; ComponentLink2Description: https://datasheet.ciiva.com/2453/pyu-r-int-thick-7-2453634.pdf?src-supplier=Digi-Key; ComponentLink1URL: https://datasheet.ciiva.com/11808/0900766b80c66297-11808787.pdf?src-supplier=RSComponents; ComponentLink1Description: https://datasheet.ciiva.com/11808/0900766b80c66297-11808787.pdf?src-supplier=RSComponents; Component Kind: Standard; Case Code (Metric): 1005; Case Code (Imperial): 0402; Case/Package: 0402
R7 = 806k 0402: RES SMD 806K OHM 1% 1/10W 0402; Manufacturer Part Number: ERJ-2RKF8063X; Manufacturer: Panasonic; Voltage Rating (DC): 50V; Temperature Coefficient: 100ppm/°C; Resistance: 806kR; Power Rating: 100mW; Width: 0.02inch; Voltage Rating: 50V; Tolerance: 1%; Termination: SMD/SMT; Supplier Part Number 1: P806KLCT-ND; Supplier 1: Digi-Key; Stock 1: 231213; RoHS: Yes; REACH SVHC: No SVHC; Pricing 1: 1 = 0.10000, 10 = 0.04500, 100 = 0.01830, 1000 = 0.00823, 2500 = 0.00715, 5000 = 0.00590 (USD); Packaging: Tape and Reel; Package Quantity: 10000; Number of Terminations: 2; Mount: Surface Mount; Min Operating Temperature: -55°C; Military Standard: Not; Max Power Dissipation: 100mW; Max Operating Temperature: 155°C; Library Name: Resistors.SchLib; Length: 1mm; Height: 0.35mm; Features: Automotive AEC-Q200; Depth: 0.5mm; Contact Plating: Tin; Composition: Thick Film; ComponentLink7URL: https://datasheet.ciiva.com/9179/aoa0000ce2-9179932.pdf?src-supplier=Avnet; ComponentLink7Description: https://datasheet.ciiva.com/9179/aoa0000ce2-9179932.pdf?src-supplier=Avnet; ComponentLink6URL: https://datasheet.ciiva.com/7753/1678782-7753996.pdf?src-supplier=Element14; ComponentLink6Description: https://datasheet.ciiva.com/7753/1678782-7753996.pdf?src-supplier=Element14; ComponentLink5URL: https://datasheet.ciiva.com/16759/70260748-16759515.pdf?src-supplier=Allied+Electronics; ComponentLink5Description: https://datasheet.ciiva.com/16759/70260748-16759515.pdf?src-supplier=Allied+Electronics; ComponentLink4URL: https://datasheet.ciiva.com/22668/1678782-22668410.pdf?src-supplier=Element14; ComponentLink4Description: https://datasheet.ciiva.com/22668/1678782-22668410.pdf?src-supplier=Element14; ComponentLink3URL: https://datasheet.ciiva.com/9179/aoa0000ce2-9179930.pdf?src-supplier=Avnet; ComponentLink3Description: https://datasheet.ciiva.com/9179/aoa0000ce2-9179930.pdf?src-supplier=Avnet; ComponentLink2URL: https://datasheet.ciiva.com/24026/aoa0000ce2-24026935.pdf?src-supplier=Mouser; ComponentLink2Description: https://datasheet.ciiva.com/24026/aoa0000ce2-24026935.pdf?src-supplier=Mouser; ComponentLink1URL: https://datasheet.ciiva.com/16785/70260748-16785671.pdf?src-supplier=Allied+Electronics; ComponentLink1Description: https://datasheet.ciiva.com/16785/70260748-16785671.pdf?src-supplier=Allied+Electronics; Component Kind: Standard; Case Code (Metric): 1005; Case Code (Imperial): 0402; Case/Package: 0402
R8 = 2M 0402: RES SMD 2M OHM 1% 1/16W 0402; Manufacturer Part Number: RC1005F205CS; Manufacturer: Samsung; Voltage Rating (DC): 50V; Temperature Coefficient: 100ppm/°C; Resistance: 2MR; Max Power Dissipation: 63mW; Width: 0.02inch; Tolerance: 1%; Supplier Part Number 1: 1276-4293-1-ND; Supplier 1: Digi-Key; Stock 1: 975529; RoHS: Yes; Radiation Hardening: No; Pricing 1: 1 = 0.10000, 10 = 0.02000, 100 = 0.00830, 1000 = 0.00373, 2500 = 0.00324, 5000 = 0.00267 (USD); Packaging: Tape and Reel; Number of Terminations: 2; Min Operating Temperature: -55°C; Max Operating Temperature: 155°C; Library Name: Resistors.SchLib; Length: 0.039inch; Lead Free: Lead Free; Height: 0.016inch; Features: Moisture Resistant; Contact Plating: Tin; Composition: Thick Film; ComponentLink2URL: https://datasheet.ciiva.com/26159/chip-resistor-150112-1-26159486.pdf?src-supplier=Digi-Key; ComponentLink2Description: https://datasheet.ciiva.com/26159/chip-resistor-150112-1-26159486.pdf?src-supplier=Digi-Key; ComponentLink1URL: https://datasheet.ciiva.com/26159/chip-resistor-150112-1-26159468.pdf?src-supplier=Digi-Key; ComponentLink1Description: https://datasheet.ciiva.com/26159/chip-resistor-150112-1-26159468.pdf?src-supplier=Digi-Key; Component Kind: Standard; Case Code (Metric): 1005; Case Code (Imperial): 0402; Case/Package: 0402
R10 = 4.7k 0402: RES SMD 4.7K OHM 1% 1/16W 0402; Manufacturer Part Number: RC0402FR-074K7L; Manufacturer: Yageo Phycomp; Voltage Rating (DC): 50V; Temperature Coefficient: 100ppm/°C; Resistance: 4.7kR; Power Rating: 63mW; Tolerance: 1%; Supplier Part Number 1: 311-4.7KLRCT-ND; Supplier 1: Digi-Key; Stock 1: 27113504; RoHS: Yes; REACH SVHC: No SVHC; Pricing 1: 1 = 0.10000, 10 = 0.01600, 100 = 0.00670, 1000 = 0.00301, 2500 = 0.00261, 5000 = 0.00215 (USD); Packaging: Cut Tape; Number of Pins: 2; Mount: Surface Mount; Min Operating Temperature: -55°C; Max Operating Temperature: 155°C; Library Name: Resistors.SchLib; Length: 1mm; Lead Free: Lead Free; Height: 0.32mm; Depth: 0.5mm; ComponentLink6URL: http://www.farnell.com/datasheets/2167052.pdf?src-supplier=Newark; ComponentLink6Description: http://www.farnell.com/datasheets/2167052.pdf?src-supplier=Newark; ComponentLink5URL: http://documents.rs-components.com/techlib://itc_doclist_GB.htm?stockno=6184558&src-supplier=RSComponents; ComponentLink5Description: http://documents.rs-components.com/techlib://itc_doclist_GB.htm?stockno=6184558&src-supplier=RSComponents; ComponentLink4URL: http://www.farnell.com/datasheets/1018594.pdf?src-supplier=Element14; ComponentLink4Description: http://www.farnell.com/datasheets/1018594.pdf?src-supplier=Element14; ComponentLink3URL: http://www.yageo.com/documents/recent/PYu-RC_Group_51_RoHS_L_9.pdf?src-supplier=Digi-Key; ComponentLink3Description: http://www.yageo.com/documents/recent/PYu-RC_Group_51_RoHS_L_9.pdf?src-supplier=Digi-Key; ComponentLink2URL: http://www.yageo.com/documents/recent/PYu-RC_Group_51_RoHS_L_10.pdf?src-supplier=Digi-Key; ComponentLink2Description: http://www.yageo.com/documents/recent/PYu-RC_Group_51_RoHS_L_10.pdf?src-supplier=Digi-Key; ComponentLink1URL: http://www.farnell.com/datasheets/2167052.pdf?src-supplier=Farnell; ComponentLink1Description: http://www.farnell.com/datasheets/2167052.pdf?src-supplier=Farnell; Component Kind: Standard; Case/Package: 0402
R12 = 0R 0402: RES SMD 0 OHM JUMPER 1/16W 0402; Manufacturer Part Number: RC0402FR-070RL; Manufacturer: Yageo; Voltage Rating: 50V; Tolerance: 1%; Temperature Coefficient: 200ppm/°C; Resistance: 0mR; Power Rating: 62.5mW; Width: 0.5mm; Termination: SMD/SMT; Supplier Part Number 1: 311-0.0LRCT-ND; Supplier 1: Digi-Key; Stock 1: 12597172; RoHS: Yes; Radiation Hardening: No; Pricing 1: 1 = 0.10000, 10 = 0.01400, 25 = 0.01000, 50 = 0.00780, 100 = 0.00570, 250 = 0.00436, 500 = 0.00348, 1000 = 0.00257, 5000 = 0.00184 (USD); Packaging: Tape and Reel; Mount: Surface Mount; Min Operating Temperature: -55°C; Max Operating Temperature: 155°C; Library Name: Resistors.SchLib; Length: 1mm; Lead Free: Lead Free; Height: 0.35mm; Contact Plating: Tin; Composition: Thick Film; ComponentLink4URL: https://datasheet.ciiva.com/16869/pyu-rc0402-51-rohs-l-6-16869518.pdf?src-supplier=Avnet+Europe; ComponentLink4Description: https://datasheet.ciiva.com/16869/pyu-rc0402-51-rohs-l-6-16869518.pdf?src-supplier=Avnet+Europe; ComponentLink3URL: https://datasheet.ciiva.com/28419/pyu-rc0402-51-rohs-l-6-28419661.pdf?src-supplier=Avnet+Europe; ComponentLink3Description: https://datasheet.ciiva.com/28419/pyu-rc0402-51-rohs-l-6-28419661.pdf?src-supplier=Avnet+Europe; ComponentLink2URL: https://datasheet.ciiva.com/16790/pyu-rc0402-51-rohs-l-6-r-16790444.pdf?src-supplier=Future+Electronics; ComponentLink2Description: https://datasheet.ciiva.com/16790/pyu-rc0402-51-rohs-l-6-r-16790444.pdf?src-supplier=Future+Electronics; ComponentLink1URL: https://datasheet.ciiva.com/8896/pyu-rc0402-51-rohs-l-6-8896149.pdf?src-supplier=Avnet; ComponentLink1Description: https://datasheet.ciiva.com/8896/pyu-rc0402-51-rohs-l-6-8896149.pdf?src-supplier=Avnet; Component Kind: Standard; Case Code (Metric): 1005; Case Code (Imperial): 0402; Case/Package: 0402
R13 = 100k 0402: RES SMD 100K OHM 1% 1/16W 0402; Manufacturer Part Number: RC0402FR-07100KL; Manufacturer: Yageo; Working Voltage: 50V; Temperature Coefficient: 100ppm/°C; Resistance: 100kR; Power Rating: 63mW; Width: 0.02inch; Voltage Rating: 50V; Tolerance: 1%; Termination: SMD/SMT; Supplier Part Number 1: 311-100KLRCT-ND; Supplier 1: Digi-Key; Stock 1: 64634513; RoHS: Yes; REACH SVHC: No SVHC; Pricing 1: 1 = 0.10000, 10 = 0.01600, 100 = 0.00670, 1000 = 0.00301, 2500 = 0.00261, 5000 = 0.00215 (USD); Packaging: Tape and Reel; Package Quantity: 1; Number of Terminations: 2; Min Operating Temperature: -55°C; Military Standard: Not; Max Power Dissipation: 63mW; Max Operating Temperature: 155°C; Library Name: Resistors.SchLib; Length: 0.039inch; Lead Free: Lead Free; Height: 0.016inch; Features: Moisture Resistant; Depth: 0.5mm; Composition: Thick Film; ComponentLink8URL: https://datasheet.ciiva.com/12667/pyu-rc0402-51-rohs-l-6-r-12667930.pdf?src-supplier=Mouser; ComponentLink8Description: https://datasheet.ciiva.com/12667/pyu-rc0402-51-rohs-l-6-r-12667930.pdf?src-supplier=Mouser; ComponentLink7URL: https://datasheet.ciiva.com/16740/pyu-rc0402-51-rohs-l-6-r-16740567.pdf?src-supplier=Future+Electronics; ComponentLink7Description: https://datasheet.ciiva.com/16740/pyu-rc0402-51-rohs-l-6-r-16740567.pdf?src-supplier=Future+Electronics; ComponentLink6URL: https://datasheet.ciiva.com/15189/getdatasheetpartid-323696-15189334.pdf?src-supplier=Verical; ComponentLink6Description: https://datasheet.ciiva.com/15189/getdatasheetpartid-323696-15189334.pdf?src-supplier=Verical; ComponentLink5URL: https://datasheet.ciiva.com/22747/1018594-22747509.pdf?src-supplier=Element14; ComponentLink5Description: https://datasheet.ciiva.com/22747/1018594-22747509.pdf?src-supplier=Element14; ComponentLink4URL: https://datasheet.ciiva.com/2453/pyu-r-int-thick-7-2453363.pdf?src-supplier=Digi-Key; ComponentLink4Description: https://datasheet.ciiva.com/2453/pyu-r-int-thick-7-2453363.pdf?src-supplier=Digi-Key; ComponentLink3URL: https://datasheet.ciiva.com/2453/pyu-r-int-thick-7-2453362.pdf?src-supplier=Digi-Key; ComponentLink3Description: https://datasheet.ciiva.com/2453/pyu-r-int-thick-7-2453362.pdf?src-supplier=Digi-Key; ComponentLink2URL: https://datasheet.ciiva.com/2453/pyu-r-int-thick-7-2453361.pdf?src-supplier=Digi-Key; ComponentLink2Description: https://datasheet.ciiva.com/2453/pyu-r-int-thick-7-2453361.pdf?src-supplier=Digi-Key; ComponentLink1URL: https://datasheet.ciiva.com/11819/0900766b80c66297-11819452.pdf?src-supplier=RSComponents; ComponentLink1Description: https://datasheet.ciiva.com/11819/0900766b80c66297-11819452.pdf?src-supplier=RSComponents; Component Kind: Standard; Case Code (Metric): 1005; Case Code (Imperial): 0402; Case/Package: 0402
R14 = 3.57k 0402: RES SMD 3.57K OHM 1% 1/10W 0402; Manufacturer Part Number: ERJ-2RKF3571X; Manufacturer: Panasonic; Voltage Rating (DC): 50V; Temperature Coefficient: 100ppm/°C; Resistance: 3.57kR; Power Rating: 100mW; Width: 0.02inch; Voltage Rating: 50V; Tolerance: 1%; Termination: SMD/SMT; Supplier Part Number 1: P3.57KLCT-ND; Supplier 1: Digi-Key; Stock 1: 676573; REACH SVHC: No SVHC; Pricing 1: 1=0.1, 10=0.043, 100=0.0176, 1000=0.00791, 2500=0.00686, 5000=0.00567 (USD); Packaging: Tape and Reel; Package Quantity: 1; Number of Terminations: 2; Mount: Surface Mount; Min Operating Temperature: -55°C; Military Standard: Not; Max Power Dissipation: 100mW; Max Operating Temperature: 155°C; Library Name: Resistors.SchLib; Length: 1mm; Lead Free: Lead Free; Height: 0.016inch; Depth: 0.5mm; Contact Plating: Tin; Composition: Thick Film; ComponentLink6URL: https://datasheet.ciiva.com/22681/1678782-22681293.pdf; ComponentLink6Description: https://datasheet.ciiva.com/22681/1678782-22681293.pdf; ComponentLink5URL: https://datasheet.ciiva.com/16779/70260748-16779437.pdf; ComponentLink5Description: https://datasheet.ciiva.com/16779/70260748-16779437.pdf; ComponentLink4URL: https://datasheet.ciiva.com/30191/aoa0000ce2-30191243.pdf; ComponentLink4Description: https://datasheet.ciiva.com/30191/aoa0000ce2-30191243.pdf; ComponentLink3URL: https://datasheet.ciiva.com/7763/1678782-7763707.pdf; ComponentLink3Description: https://datasheet.ciiva.com/7763/1678782-7763707.pdf; ComponentLink2URL: https://datasheet.ciiva.com/28545/1694929-28545241.pdf; ComponentLink2Description: https://datasheet.ciiva.com/28545/1694929-28545241.pdf; ComponentLink1URL: https://datasheet.ciiva.com/24069/aoa0000ce2-24069909.pdf; ComponentLink1Description: https://datasheet.ciiva.com/24069/aoa0000ce2-24069909.pdf; Component Kind: Standard; Case Code (Metric): 1005; Case Code (Imperial): 0402; Case/Package: 0402
R17 = 1.15k 0402: RES SMD 1.15K OHM 1% 1/10W 0402; Manufacturer Part Number: ERJ-2RKF1151X; Manufacturer: Panasonic; Voltage Rating (DC): 50V; Temperature Coefficient: 100ppm/°C; Resistance: 1.15kR; Power Rating: 100mW; Width: 0.5mm; Voltage Rating: 50V; Tolerance: 1%; Termination: SMD/SMT; Supplier Part Number 1: P1.15KLCT-ND; Supplier 1: Digi-Key; Stock 1: 99704; REACH SVHC: Yes; Radiation Hardening: No; Pricing 1: 1=0.1, 10=0.043, 100=0.0176, 1000=0.00791, 2500=0.00686, 5000=0.00567 (USD); Packaging: Tape and Reel; Package Quantity: 1; Number of Terminations: 2; Mount: Surface Mount; Min Operating Temperature: -55°C; Military Standard: Not; Max Power Dissipation: 100mW; Max Operating Temperature: 155°C; Library Name: Resistors.SchLib; Length: 1mm; Lead Free: Lead Free; Height: 0.35mm; Depth: 0.5mm; Contact Plating: Tin; Composition: Thick Film; ComponentLink6URL: https://datasheet.ciiva.com/16768/70260748-16768765.pdf; ComponentLink6Description: https://datasheet.ciiva.com/16768/70260748-16768765.pdf; ComponentLink5URL: https://datasheet.ciiva.com/46373/1678782-46373833.pdf; ComponentLink5Description: https://datasheet.ciiva.com/46373/1678782-46373833.pdf; ComponentLink4URL: https://datasheet.ciiva.com/46373/1678782-46373832.pdf; ComponentLink4Description: https://datasheet.ciiva.com/46373/1678782-46373832.pdf; ComponentLink3URL: https://datasheet.ciiva.com/43467/1678782-43467317.pdf; ComponentLink3Description: https://datasheet.ciiva.com/43467/1678782-43467317.pdf; ComponentLink2URL: https://datasheet.ciiva.com/24027/aoa0000ce2-24027413.pdf; ComponentLink2Description: https://datasheet.ciiva.com/24027/aoa0000ce2-24027413.pdf; ComponentLink1URL: https://datasheet.ciiva.com/22721/1678782-22721221.pdf; ComponentLink1Description: https://datasheet.ciiva.com/22721/1678782-22721221.pdf; Component Kind: Standard; Case Code (Metric): 1005; Case Code (Imperial): 0402; Case/Package: 0402
